# Altium schematic sheet Microphone PreAmp.SchDoc("Microphone PreAmp")
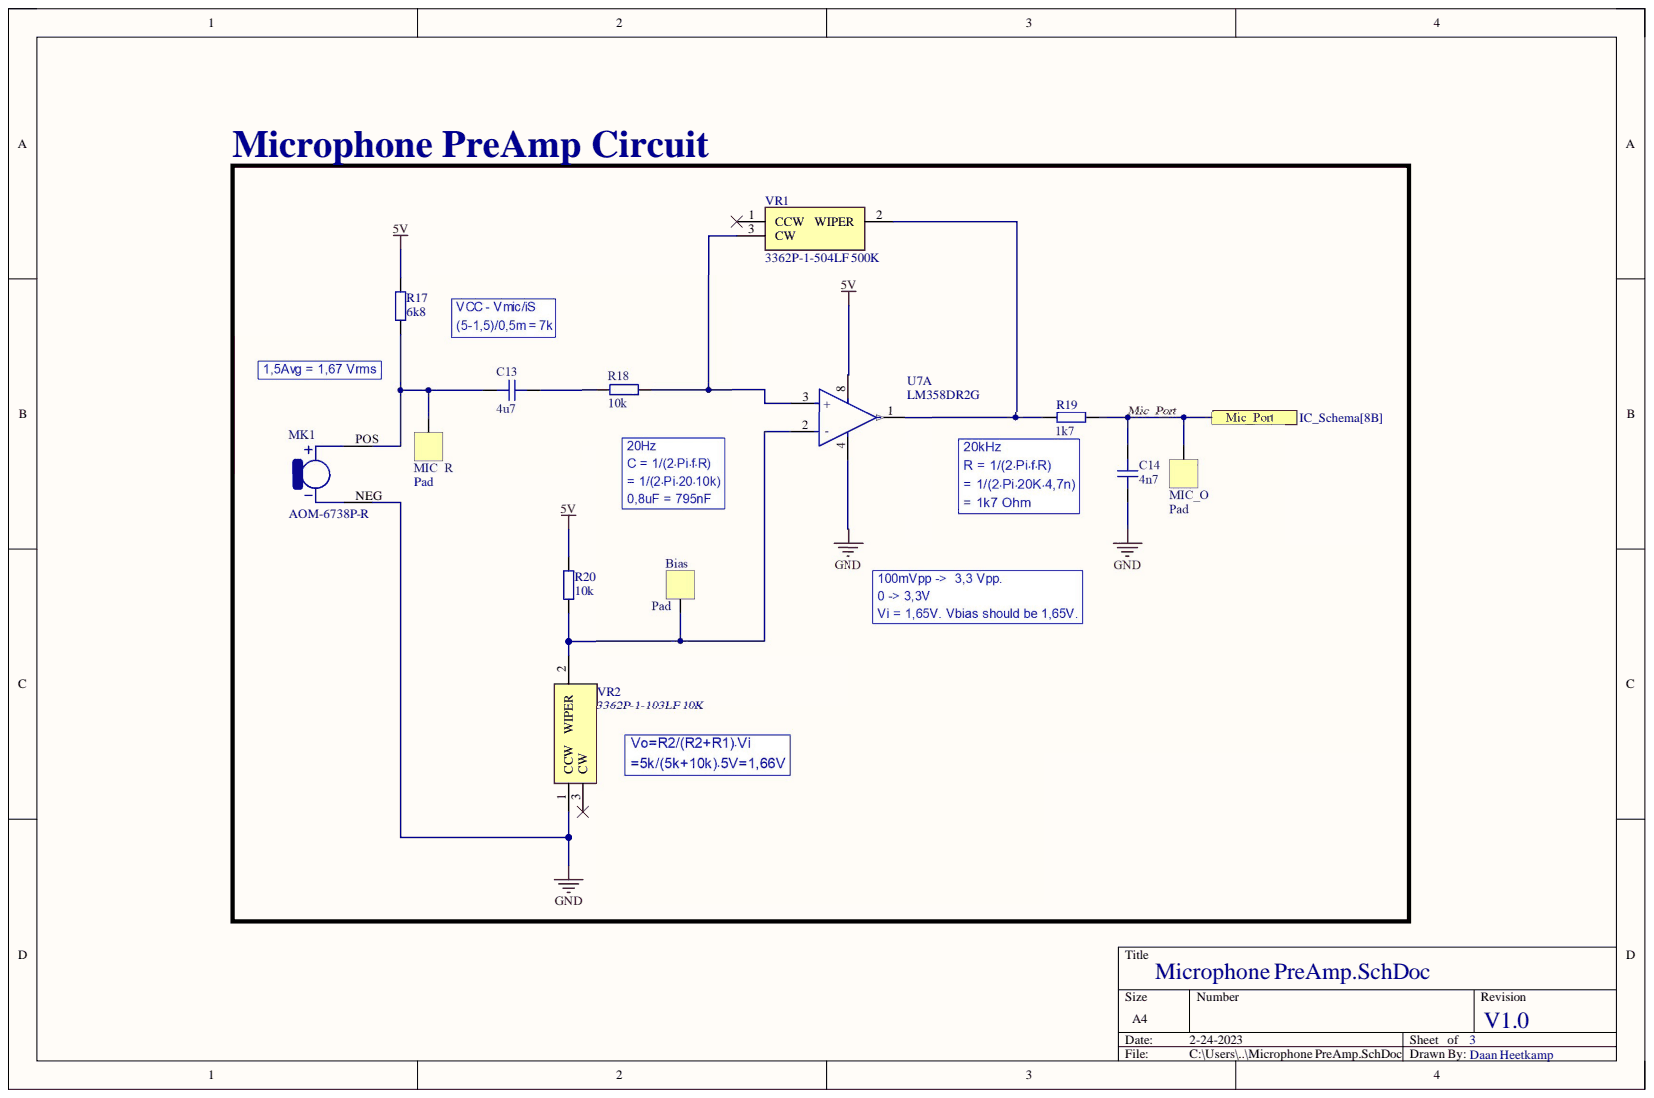
[diagram p0: Microphone PreAmp.SchDoc]

## Parts
Bias  Pad  [PAD_SQ_2MM]
C13  4u7  [CAP_0603]
C14  4n7  [CAP_0603]
MIC_O  Pad  [PAD_SQ_2MM]
MIC_R  Pad  [PAD_SQ_2MM]
MK1  AOM-6738P-R  [MIC_AOM-6738P-R]
R17  6k8  [RES_1206]
R18  10k  [RES_1206]
R19  1k7  [RES_1206]
R20  10k  [RES_1206]
U7  LM358DR2G  [FP-751-07-IPC_C]  (part A)
VR1  3362P-1-504LF 500K  [3362P1504LF]
VR2  3362P-1-103LF 10K  [3362P1504LF]

## Ports
Mic_Port

## Nets
5V: R17.1 R20.2 U7.8
GND: C14.2 MK1.NEG U7.4 VR2.1
Mic_Port: C14.1 MIC_O.1 R19.1 ; port Mic_Port
NetBias_1: Bias.1 R20.1 U7.2(-) VR2.2
NetC13_1: C13.1 R18.2
NetC13_2: C13.2 MIC_R.1 MK1.POS R17.2
NetR18_1: R18.1 U7.3(+) VR1.3
NetR19_2: R19.2 U7.1 VR1.2

Not connected: VR1 1; VR2 3

## Library data of the parts
Bias MIC_O MIC_R = PAD_SQ_2MM: Test Point Square 2mm; Component Kind: Standard; Library Name: Schem_Lib_DaanHeetkamp..SchLib
C13 C14 = CAP_0603_50V: Capacitor SMD 0603 50V; Component Kind: Standard; Library Name: Schem_Lib_DaanHeetkamp.SchLib
MK1 = AOM-6738P-R: 30Hz ~ 15kHz Analog Microphone Electret Condenser 1.5V ~ 10V Omnidirectional _-38dB Â±3dB_ PC Pins; MF: PUI; Availability: Unavailable; Component Kind: Standard; MP: AOM-6738P-R; Package: PUI; Price: None; SnapEDA_Link: https://www.snapeda.com/parts/AOM-6738P-R/PUI%20Audio%2C%20Inc./view-part/246102/?ref=snap; Library Name: Schem_Lib_DaanHeetkamp..SchLib
R17-R20 = RES_1206: Resistor SMD 1206; Component Kind: Standard; Library Name: Schem_Lib_DaanHeetkamp..SchLib
U7 = CMP-1310-00291-2: IC OPAMP GP 2 CIRCUIT 8SOIC; Bandwidth: 1MHz; Common Mode Rejection Ratio: 65dB; Dual Supply Voltage: 9V; Input Bias Current: 250nA; Input Offset Voltage (Vos): 7mV; Max Dual Supply Voltage: 16V; Max Supply Voltage: 32V; Min Dual Supply Voltage: 1.5V; Min Supply Voltage: 3V; Nominal Supply Current: 1.2mA; Number of Amplifiers: 2; Operating Supply Current: 1.5mA; Output Current: 40mA; Slew Rate: 0.6V/µs; Voltage Gain: 100dB; Case/Package: SOIC; Component Kind: Standard; Contact Plating: Tin; Gain Bandwidth Product: 1MHz; Halogen Free: Halogen Free; Height: 1.5mm; Lead Free: Lead Free; Length: 5mm; Library Name: Altium Content Vault; Max Operating Temperature: 70°C; Min Operating Temperature: 0°C; Number of Channels: 2; Number of Circuits: 2; Number of Elements: 2; Number of Pins: 8; Operating Supply Voltage: 16V; Output Current per Channel: 40mA; Package Quantity: 2500; Packaging: Tape and Reel; Power Supply Rejection Ratio (PSRR): 65dB; Radiation Hardening: No; REACH SVHC: No SVHC; Width: 4mm
VR1 VR2 = 3362P-1-504LF: Variable Resistor; Manufacturer_Name: Bourns; Arrow Part Number: 3362P-1-504LF; Arrow Price/Stock: https://www.arrow.com/en/products/3362p-1-504lf/bourns?region=nac; Component Kind: Standard; Datasheet Link: https://componentsearchengine.com/Datasheets/2/3362P-1-504LF.pdf; Height: 4.95mm; Manufacturer_Part_Number: 3362P-1-504LF; Mouser Part Number: 652-3362P-1-504LF; Mouser Price/Stock: https://www.mouser.co.uk/ProductDetail/Bourns/3362P-1-504LF?qs=PcXFNxoHkaI%252Brk7xgs916g%3D%3D; Library Name: Schem_Lib_DaanHeetkamp..SchLib
